# Altium schematic sheet MCU及其外围电路.SchDoc(MCU及其外围电路)
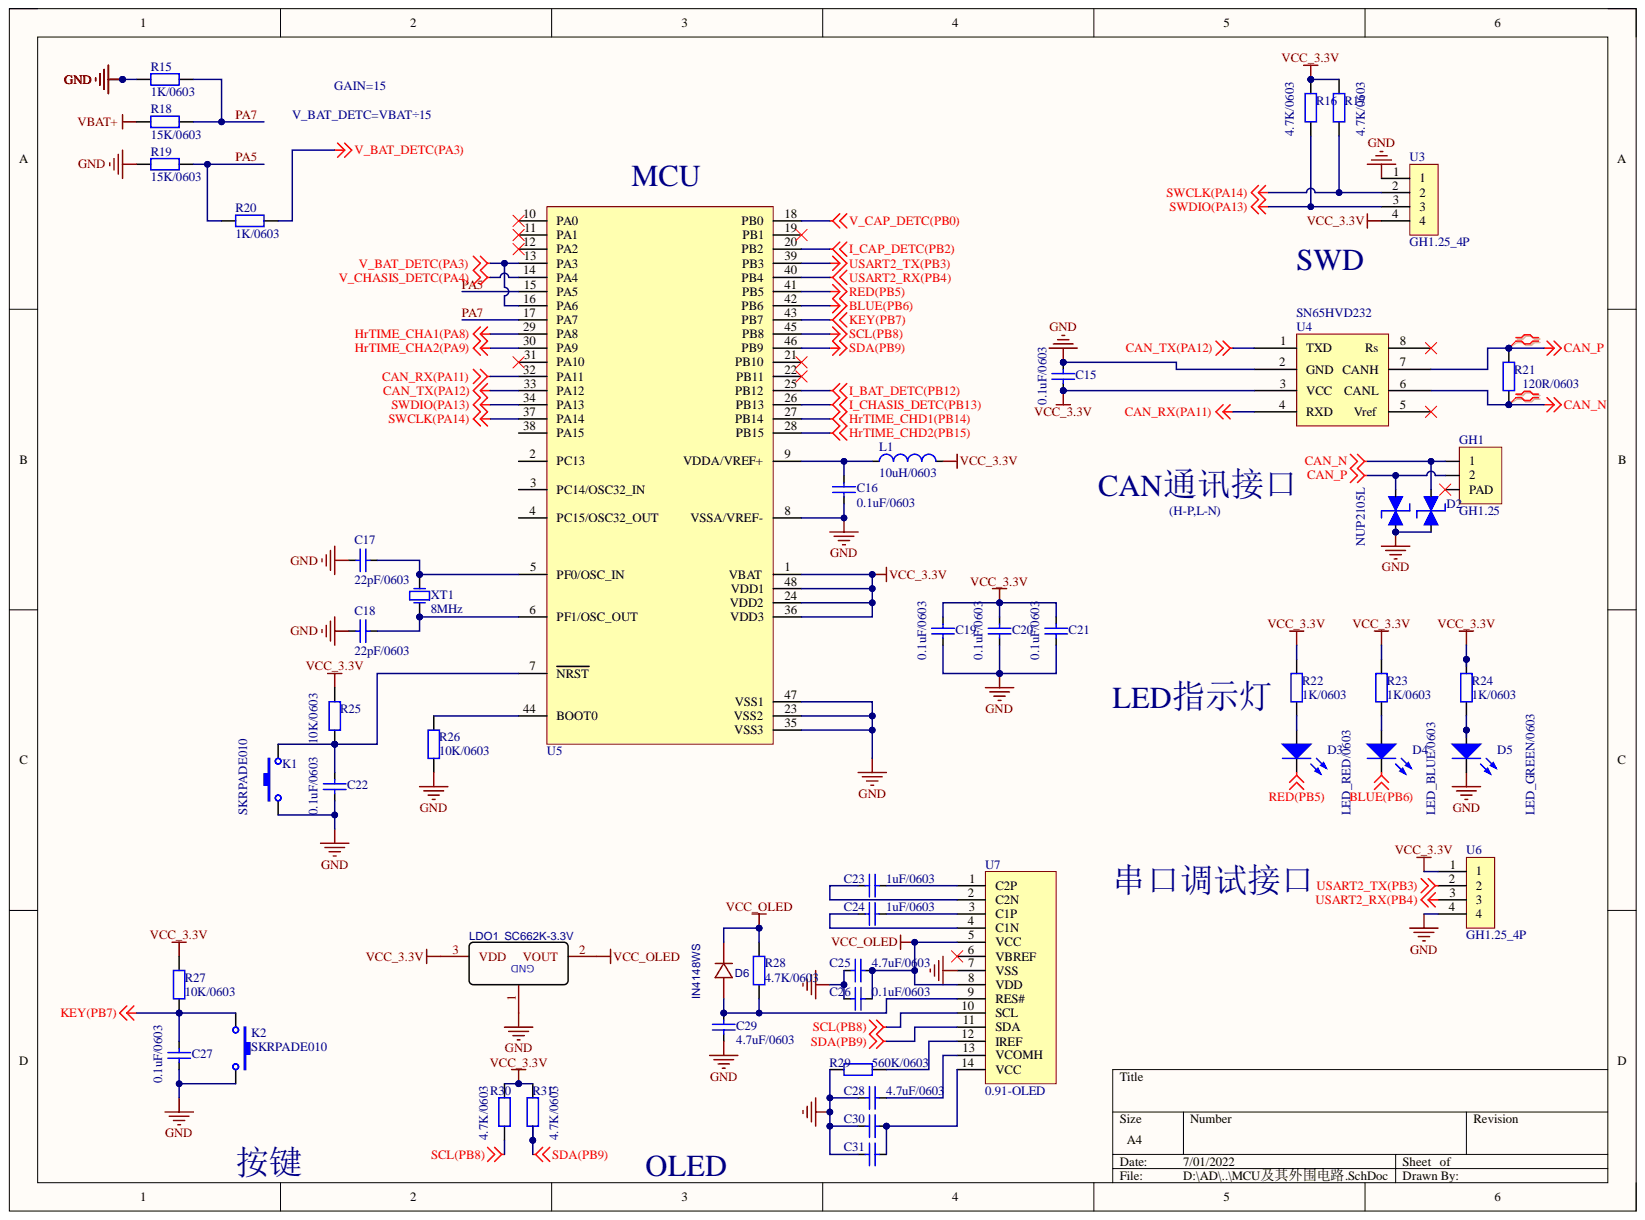
[diagram p0: MCU及其外围电路.SchDoc]

## Parts
C15  0.1uF  value 0.1uF/0603  [CAP_0603]
C16  0.1uF  value 0.1uF/0603  [CAP_0603]
C17  22pF  value 22pF/0603  [CAP_0603]
C18  22pF  value 22pF/0603  [CAP_0603]
C19  0.1uF  value 0.1uF/0603  [CAP_0603]
C20  0.1uF  value 0.1uF/0603  [CAP_0603]
C21  0.1uF  value 0.1uF/0603  [CAP_0603]
C22  0.1uF  value 0.1uF/0603  [CAP_0603]
C23  1uF  value 1uF/0603  [CAP_0603]
C24  1uF  value 1uF/0603  [CAP_0603]
C25  4.7uF  value 4.7uF/0603  [CAP_0603]
C26  0.1uF  value 0.1uF/0603  [CAP_0603]
C27  0.1uF  value 0.1uF/0603  [CAP_0603]
C28  4.7uF  value 4.7uF/0603  [CAP_0603]
C29  4.7uF  value 4.7uF/0603  [CAP_0603]
C30  0.1uF  [CAP_0603]  (value not drawn)
C31  0.1uF  [CAP_0603]  (value not drawn)
D2  NUP2105L  [SOT23]
D3  value LED_RED/0603  [LED_0603_RED]  (hidden comment: LED_0603_RED)
D4  value LED_BLUE/0603  [LED_0603_BLUE]  (hidden comment: LED_0603_BLUE)
D5  value LED_GREEN/0603  [LED_0603_GREEN]  (hidden comment: LED_0603_GREEN)
D6  IN4148WS  [SOD323]
GH1  GH1.25  [GH1.25-WS-2P]
K1  SKRPADE010  [SKRPADE010]
K2  SKRPADE010  [SKRPADE010]
L1  10uH  value 10uH/0603  [IND_0603]
LDO1  SC662K-3.3V  [SOT23-3]
R15  1K  value 1K/0603  [RES_0603]
R16  4.7K  value 4.7K/0603  [RES_0603]
R17  4.7K  value 4.7K/0603  [RES_0603]
R18  15K  value 15K/0603  [RES_0603]
R19  15K  value 15K/0603  [RES_0603]
R20  1K  value 1K/0603  [RES_0603]
R21  120R  value 120R/0603  [RES_0603]
R22  1K  value 1K/0603  [RES_0603]
R23  1K  value 1K/0603  [RES_0603]
R24  1K  value 1K/0603  [RES_0603]
R25  10K  value 10K/0603  [RES_0603]
R26  10K  value 10K/0603  [RES_0603]
R27  10K  value 10K/0603  [RES_0603]
R28  4.7K  value 4.7K/0603  [RES_0603]
R29  560K  value 560K/0603  [RES_0603]
R30  4.7K  value 4.7K/0603  [RES_0603]
R31  4.7K  value 4.7K/0603  [RES_0603]
U3  GH1.25_4P  [GH1.25_4P]
U4  SN65HVD232  [SOIC_8]
U5  STM32F334CxT6  [LQFP_48]  (value not drawn)
U6  GH1.25_4P  [GH1.25_4P]
U7  0.91-OLED  [OLED0.91_I2C]
XT1  8MHz  [XTAL_5032]

## Nets
BLUE(PB6): D4.2 U5.42(PB6)
CAN_N: D2.2 GH1.1 R21.1 U4.6(CANL)
CAN_P: D2.1 GH1.2 R21.2 U4.7(CANH)
CAN_RX(PA11): U4.4(RXD) U5.32(PA11)
CAN_TX(PA12): U4.1(TXD) U5.33(PA12)
GND: C15.2 C16.2 C17.2 C18.2 C19.1 C20.1 C21.1 C22.1 C25.1 C26.1 C27.1 C28.1 C29.1 C30.2 C31.2 D2.3 D5.2 K1.2 K2.2 LDO1.1 R15.1 R19.1 R26.1 R29.2 U3.1 U4.2(GND) U5.8(VSSA/VREF-) U5.23(VSS2) U5.35(VSS3) U5.47(VSS1) U6.4 U7.7(VSS)
HrTIME_CHA1(PA8): U5.29(PA8)
HrTIME_CHA2(PA9): U5.30(PA9)
HrTIME_CHD1(PB14): U5.27(PB14)
HrTIME_CHD2(PB15): U5.28(PB15)
I_BAT_DETC(PB12): U5.25(PB12)
I_CAP_DETC(PB2): U5.20(PB2)
I_CHASIS_DETC(PB13): U5.26(PB13)
KEY(PB7): C27.2 K2.1 R27.1 U5.43(PB7)
RED(PB5): D3.2 U5.41(PB5)
SCL(PB8): R30.1 U5.45(PB8) U7.10(SCL)
SDA(PB9): R31.1 U5.46(PB9) U7.11(SDA)
SWCLK(PA14): R17.1 U3.2 U5.37(PA14)
SWDIO(PA13): R16.1 U3.3 U5.34(PA13)
USART2_RX(PB4): U5.40(PB4) U6.3
USART2_TX(PB3): U5.39(PB3) U6.2
V_BAT_DETC(PA3): R20.2 U5.13(PA3) U5.16(PA6)
V_CAP_DETC(PB0): U5.18(PB0)
V_CHASIS_DETC(PA4): U5.14(PA4)
VBAT+: R18.1
VCC_3.3V: C15.1 C19.2 C20.2 C21.2 L1.2 LDO1.3 R16.2 R17.2 R22.2 R23.1 R24.2 R25.2 R27.2 R30.2 R31.2 U3.4 U4.3(VCC) U5.1(VBAT) U5.24(VDD2) U5.36(VDD3) U5.48(VDD1) U6.1
VCC_OLED: C25.2 C26.2 D6.2 LDO1.2 R28.2 U7.5(VCC) U7.8(VDD)
PA5: R19.2 R20.1 U5.15(PA5)
PA7: R15.2 R18.2 U5.17(PA7)
NetC16_1: C16.1 L1.1 U5.9(VDDA/VREF+)
NetC17_1: C17.1 U5.5(PF0/OSC_IN) XT1.2
NetC18_1: C18.1 U5.6(PF1/OSC_OUT) XT1.1
NetC22_2: C22.2 K1.1 R25.1 U5.7(NRST)
NetC23_1: C23.1 U7.2(C2N)
NetC23_2: C23.2 U7.1(C2P)
NetC24_1: C24.1 U7.4(C1N)
NetC24_2: C24.2 U7.3(C1P)
NetC28_2: C28.2 U7.13(VCOMH)
NetC29_2: C29.2 D6.1 R28.1 U7.9(RES#)
NetC30_1: C30.1 C31.1 U7.14(VCC)
NetD3_1: D3.1 R22.1
NetD4_1: D4.1 R23.2
NetD5_1: D5.1 R24.1
NetR26_2: R26.2 U5.44(BOOT0)
NetR29_1: R29.1 U7.12(IREF)

Not connected: GH1 0; U4 5(Vref) 8(Rs); U5 2(PC13) 3(PC14/OSC32_IN) 4(PC15/OSC32_OUT) 10(PA0) 11(PA1) 12(PA2) 19(PB1) 21(PB10) 22(PB11) 31(PA10) 38(PA15); U7 6(VBREF)

## Library data of the parts
C15-C31 = Cap_0603: Capacitor; Component Kind: Standard; Library Name: LIN_Library .SchLib
D2 = Diode_ESD(TWO CHANNEL): ESD PROTECTION DIODE(TWO CHANNEL); Component Kind: Standard; Library Name: LIN_Library .SchLib
D3 = LED_0603_RED: LED; Component Kind: Standard; Library Name: HE_Library.SchLib
D4 = LED_0603_BLUE: LED; Component Kind: Standard; Library Name: HE_Library.SchLib
D5 = LED_0603_GREEN: LED; Component Kind: Standard; Library Name: LIN_Library .SchLib
D6 = IN4148WS: Diode; BOM_Manufacturer: Leshan Radio; Contributor: LCSC; SMT Type: Extend; BOM_LCSC Assembly: Yes; BOM_Manufacturer Part: IN4148WS; BOM_Supplier: LCSC; BOM_Supplier Part: C417545; Component Kind: Standard; spicePre: D; spiceSymbolName: IN4148WS
GH1 = GH1.25-2P: GH1.25连接器; Component Kind: Standard; Library Name: SCH_Connector.SchLib
K1 K2 = SKRPADE010: KEY; Component Kind: Standard; Library Name: Chen_Library.IntLib
L1 = Ind_0603: Inductor; Component Kind: Standard; Library Name: LIN_Library .SchLib
LDO1 = SC662K-3.3V: BOM_Manufacturer: FM; SMT Type: Extend; BOM_LCSC Assembly: Yes; BOM_Manufacturer Part: SC662K-3.3V; BOM_Supplier: LCSC; BOM_Supplier Part: C83932; Component Kind: Standard; image: //image.lceda.cn/szlcsc/C83932.jpg; spicePre: L; spiceSymbolName: SC662K-3.3V
R15-R31 = Res_0603: Resistor; Component Kind: Standard
  R15-R21 R25-R31: Library Name: LIN_Library .SchLib
  R22-R24: Library Name: HE_Library.SchLib
U3 U6 = GH1.25_4P: GH1.25连接器; Component Kind: Standard; Library Name: Chen_Library.IntLib
U4 = SN65HVD232: 3.3V CAN收发器; Component Kind: Standard; Library Name: SCH_IC.SchLib
U5 = STM32F334Cx: STM32F334C8T6; Component Kind: Standard; Library Name: LIN_Library .SchLib
U7 = 0.91-OLED: 0.91寸OLED; Component Kind: Standard; Library Name: LIN_Library .SchLib
XT1 = XTAL_SMD: Crystal Oscillator; Component Kind: Standard; LatestRevisionDate: 17-Jul-2002; LatestRevisionNote: Re-released for DXP Platform.; PackageDescription: Crystal, Thru-Hole; 2 Leads; Body 3.1 x 8.2 mm (Dia.xH); PackageReference: R38; Published: 8-Jun-2000; Value: 8MHz; Library Name: LIN_Library .SchLib; Publisher: Altium Limited
